# Altium schematic sheet powersupply.SchDoc
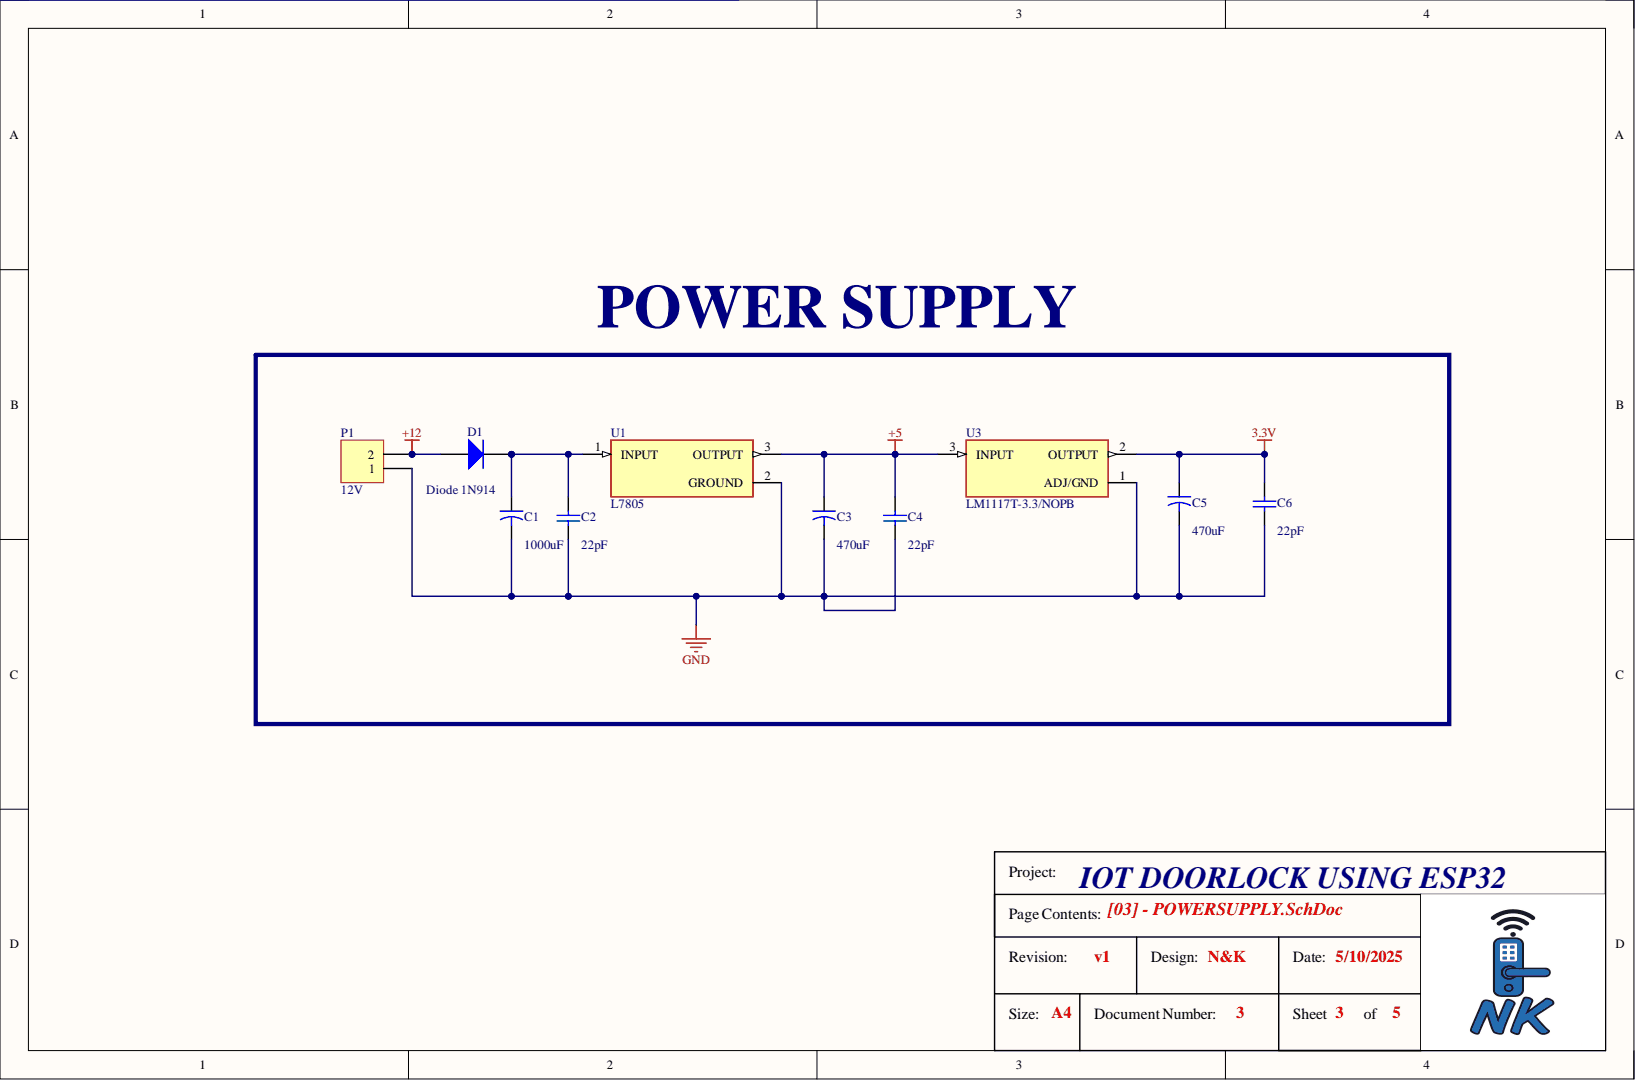
[diagram p0: powersupply.SchDoc]

## Parts
C1  value 1000uF  [CAPR5-4X5]  (hidden comment: Cap2)
C2  value 22pF  [RAD-0.3]  (hidden comment: Cap)
C3  value 470uF  [CAPR5-4X5]  (hidden comment: Cap2)
C4  value 22pF  [RAD-0.3]  (hidden comment: Cap)
C5  value 470uF  [CAPR5-4X5]  (hidden comment: Cap2)
C6  value 22pF  [RAD-0.3]  (hidden comment: Cap)
D1  Diode 1N914  [DO-35]
P1  12V  [HDR1X2]
U1  L7805  [TO255P1020X450X2000-3]
U3  LM1117T-3.3/NOPB  [TO254P1054X470X1955-3]

## Nets
3.3V: C5.1 C6.1 U3.2
+5: C3.1 C4.1 U1.3 U3.3
+12: D1.1 P1.2
GND: C1.2 C2.1 C3.2 C4.2 C5.2 C6.2 P1.1 U1.2 U3.1
NetC1_1: C1.1 C2.2 D1.2 U1.1

## Library data of the parts
C1 C3 C5 = Cap2: Capacitor; Component Kind: Standard; Library Name: Miscellaneous Devices.SchLib; PackageDescription: Capacitor; 2 Leads; PackageReference: CAPR5-4X5; Published: 23-Sep-2002; Signal Integrity: Cap; Simulation: CAP; Publisher: Altium Limited
C2 C4 C6 = Cap: Capacitor; Component Kind: Standard; LatestRevisionDate: 17-Jul-2002; LatestRevisionNote: Re-released for DXP Platform.; Library Name: Miscellaneous Devices.SchLib; PackageDescription: Radial Cap, Thru-Hole; 2 Leads; 0.3 in Pin Spacing; PackageReference: RAD-0.3; Published: 8-Jun-2000; Signal Integrity: Cap; Simulation: CAP; Publisher: Altium Limited
D1 = Diode 1N914: High Conductance Fast Diode; Code_JEDEC: DO-204-AH; Component Kind: Standard; ComponentLink1Description: Manufacturer Link; ComponentLink1URL: http://www.fairchildsemi.com/; ComponentLink2Description: Datasheet; ComponentLink2URL: http://www.fairchildsemi.com/ds/1N%2F1N4448.pdf; DatasheetVersion: 1997; LatestRevisionDate: 17-Jul-2002; LatestRevisionNote: Re-released for DXP Platform.; Library Name: Miscellaneous Devices.SchLib; PackageDescription: Diode, Axial; Body 3.8 x 1.9 mm (LxDia. max), Lead Dia. 0.53 mm (max); PackageReference: DO-35; Published: 8-Jun-2000; Signal Integrity: 1N914; Simulation: 1N914; Publisher: Altium Limited
P1 = Header 2: Header, 2-Pin; Component Kind: Standard; LatestRevisionDate: 17-Jul-2002; LatestRevisionNote: Re-released for DXP Platform.; Library Name: Miscellaneous Connectors.SchLib; Signal Integrity: Connector; Publisher: Altium Limited
U1 = L7805: Standard Regulator Pos 5V 1.5A 3-Pin(3+Tab) TO-220FP Tube; MF: STMicroelectronics; Availability: Not in stock; Check_prices: https://www.snapeda.com/parts/L7805/STMicroelectronics/view-part/?ref=eda; Component Kind: Standard; Library Name: L7805.SchLib; MP: L7805; Package: None; Price: None; SnapEDA_Link: https://www.snapeda.com/parts/L7805/STMicroelectronics/view-part/?ref=snap
U3 = LM1117T-3.3/NOPB: 800-mA 15-V linear voltage regulator; MF: Texas Instruments; Check_prices: https://www.snapeda.com/parts/LM1117T-3.3/NOPB/Texas+Instruments/view-part/?ref=eda; Component Kind: Standard; Library Name: LM1117T-3.3_NOPB.SchLib; MP: LM1117T-3.3/NOPB; Package: TO-220-3 Texas Instruments; SnapEDA_Link: https://www.snapeda.com/parts/LM1117T-3.3/NOPB/Texas+Instruments/view-part/?ref=snap
